# Altium schematic sheet CoinCraft1.SchDoc(CoinCraft1)
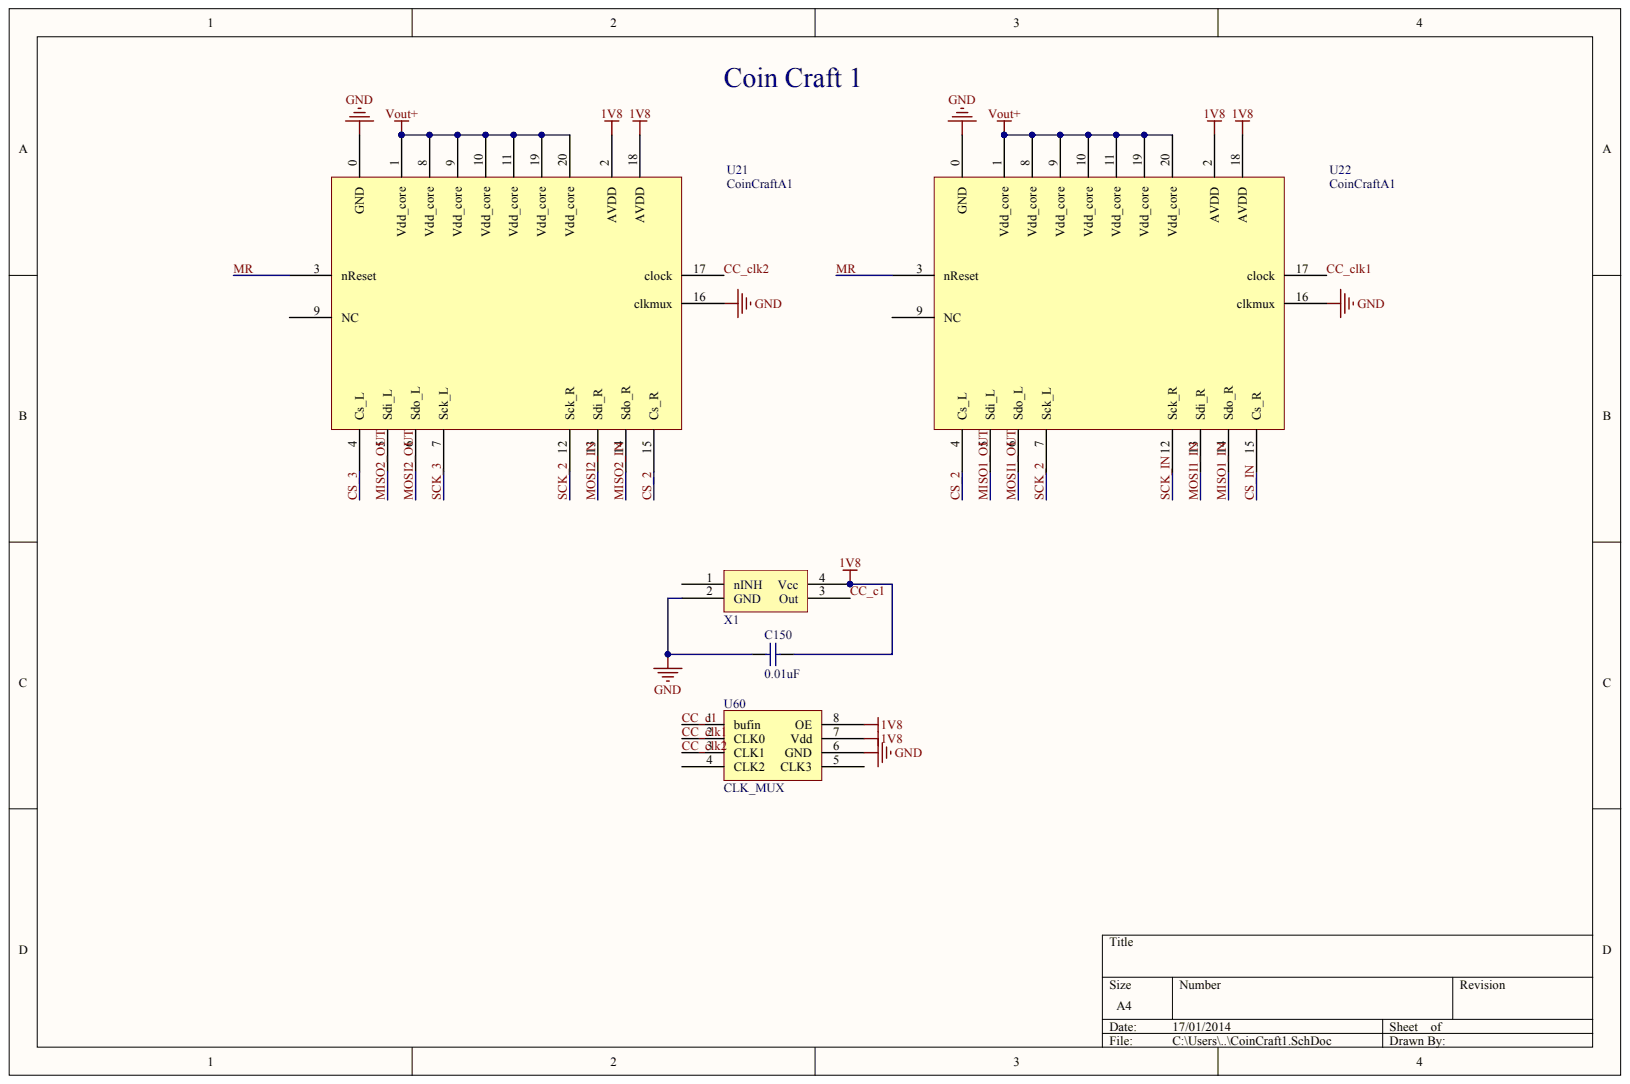
[diagram p0: CoinCraft1.SchDoc]

## Parts
C150  0.01uF
U21  CoinCraftA1
U22  CoinCraftA1
U60  CLK_MUX
X1  KC5032A-CM  (value not drawn)

## Nets
1V8: C150.2 U21.2(AVDD) U21.18(AVDD) U22.2(AVDD) U22.18(AVDD) U60.7(Vdd) U60.8(OE) X1.4(Vcc)
GND: C150.1 U21.0(GND) U21.16(clkmux) U22.0(GND) U22.16(clkmux) U60.6(GND) X1.2(GND)
VOUT+: U21.1(Vdd_core) U21.8(Vdd_core) U21.9 U21.10(Vdd_core) U21.11(Vdd_core) U21.19(Vdd_core) U21.20(Vdd_core) U22.1(Vdd_core) U22.8(Vdd_core) U22.9 U22.10(Vdd_core) U22.11(Vdd_core) U22.19(Vdd_core) U22.20(Vdd_core)
CC_C1: U60.1(bufin) X1.3(Out)
CC_CLK1: U22.17(clock) U60.2(CLK0)
CC_CLK2: U21.17(clock) U60.3(CLK1)
CS_2: U21.15(Cs_R) U22.4(Cs_L)
CS_3: U21.4(Cs_L)
CS_IN: U22.15(Cs_R)
MISO1_IN: U22.14(Sdo_R)
MISO1_OUT: U22.5(Sdi_L)
MISO2_IN: U21.14(Sdo_R)
MISO2_OUT: U21.5(Sdi_L)
MOSI1_IN: U22.13(Sdi_R)
MOSI1_OUT: U22.6(Sdo_L)
MOSI2_IN: U21.13(Sdi_R)
MOSI2_OUT: U21.6(Sdo_L)
MR: U21.3(nReset) U22.3(nReset)
SCK_2: U21.12(Sck_R) U22.7(Sck_L)
SCK_3: U21.7(Sck_L)
SCK_IN: U22.12(Sck_R)

Not connected: U60 4(CLK2) 5(CLK3); X1 1(nINH)

## Library data of the parts
C150 U21 U22 U60 X1 = (no symbol name)
  C150: Published: 8-Jun-2000; LatestRevisionDate: 17-Jul-2002; LatestRevisionNote: Re-released for DXP Platform.; PackageReference: RAD-0.3; PackageDescription: Radial Cap, Thru-Hole; 2 Leads; 0.3 in Pin Spacing; Value: 0.01uF; Publisher: Altium Limited
